# Altium schematic sheet MainPowerSupply.SchDoc
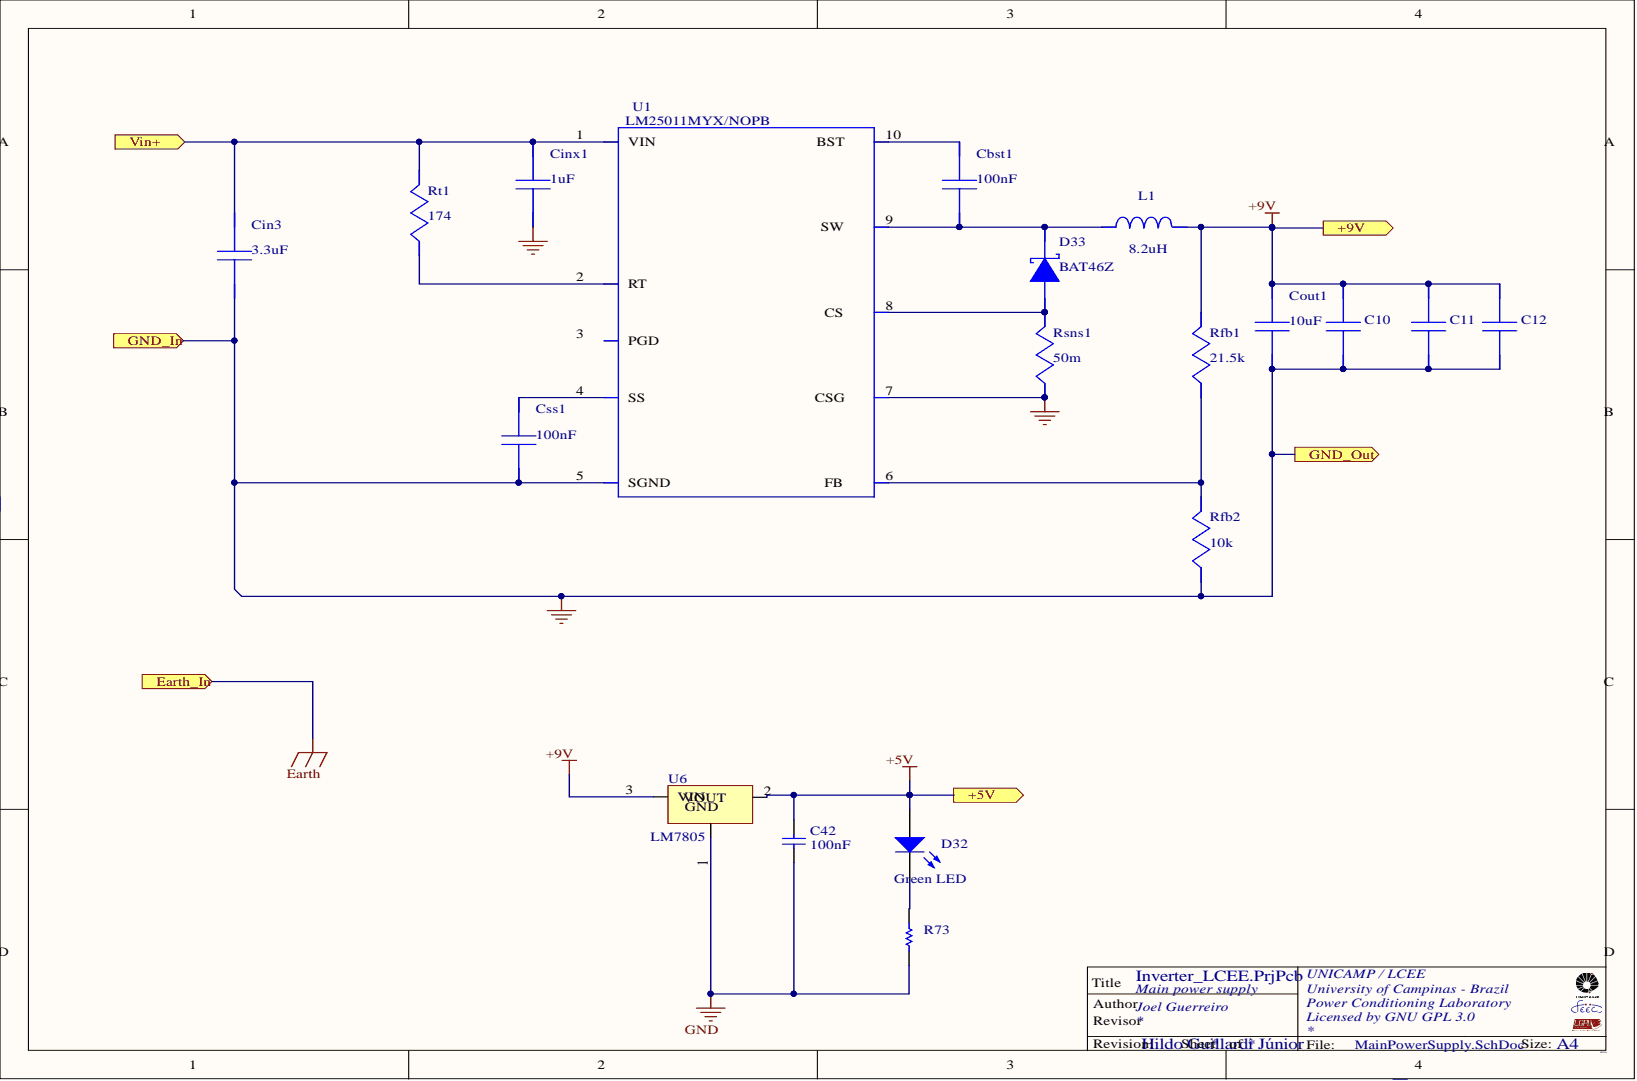
[diagram p0: MainPowerSupply.SchDoc]

## Parts
C10  (no value)  [0805_W]
C11  (no value)  [0805_W]
C12  (no value)  [0805_W]
C42  value 100nF  [0805_W]
Cbst1  value 100nF  [0805_W]
Cin3  value 3.3uF  [1210_W]
Cinx1  value 1uF  [0805_W]
Cout1  value 10uF  [0805_W]
Css1  value 100nF  [0805_W]
D32  value Green LED  [LED_0805]
D33  value BAT46Z  [SOD-123]
L1  value 8.2uH  [SRN8040T - Inductor]
R73  (no value)  [0805_W]
Rfb1  value 21.5k  [0805_W]
Rfb2  value 10k  [0805_W]
Rsns1  value 50m  [0805_W]
Rt1  value 174  [0805_W]
U1  value LM25011MYX/NOPB  [10MSOP]
U6  value LM7805  [LM7805]

## Ports
+5V, +9V, EARTH_IN, GND_IN, GND_OUT, VIN+

## Nets
0: C10.2 C11.2 C12.2 Cin3.2 Cinx1.2 Cout1.2 Css1.2 Rfb2.2 Rsns1.2 U1.5(SGND) U1.7 ; port GND_IN, port GND_OUT
GND: C42.2 R73.1 U6.1
+5V: C42.1 D32.1 U6.2 ; port +5V
+9V: C10.1 C11.1 C12.1 Cout1.1 L1.2 Rfb1.1 U6.3 ; port +9V
NetCbst1_1: Cbst1.1 U1.10
NetCbst1_2: Cbst1.2 D33.2 L1.1 U1.9
NetCin3_1: Cin3.1 Cinx1.1 Rt1.1 U1.1(VIN) ; port VIN+
NetCss1_1: Css1.1 U1.4(SS)
NetD32_2: D32.2 R73.2
NetD33_1: D33.1 Rsns1.1 U1.8
NetRfb1_2: Rfb1.2 Rfb2.1 U1.6
NetRt1_2: Rt1.2 U1.2(RT)
NetU1_3: U1.3(PGD)
EARTH: ; port EARTH_IN
N00132:
N00133:

## Library data of the parts
C10-C12 = GRM21BR61C106KE15L: GRM21BR61C106KE1; Manufacturer Name: MuRata; Component Kind: Standard; Library Name: TI WEBENCH; Manufacturer Part Number: GRM21BR61C106KE15L; PackageReference: GRM21BR61C106KE15L; Value: Value
C42 = Capacitor_nao_polarizado: Capacitor nao polarizado; Component Kind: Standard; Value: Value; Library Name: Sch_LCEE.SchLib
Cbst1 Css1 = CC0805KRX7R8BB104: CC0805KRX7R8BB10; Manufacturer Name: Yageo America; Component Kind: Standard; Library Name: TI WEBENCH; Manufacturer Part Number: CC0805KRX7R8BB104; PackageReference: CC0805KRX7R8BB104; Value: Value
Cin3 = C3225X7S2A335K200AB: C3225X7S2A335K20; Manufacturer Name: TDK; Component Kind: Standard; Library Name: TI WEBENCH; Manufacturer Part Number: C3225X7S2A335K200AB; PackageReference: C3225X7S2A335K200AB; Value: Value
Cinx1 = C1608X5R1H105K080AB: C1608X5R1H105K08; Manufacturer Name: TDK; Component Kind: Standard; Library Name: TI WEBENCH; Manufacturer Part Number: C1608X5R1H105K080AB; PackageReference: C1608X5R1H105K080AB; Value: Value
Cout1 = C0805C106K8PACTU: Manufacturer Name: Kemet; Component Kind: Standard; Description: C0805C106K8PACTU; Library Name: TI WEBENCH; Manufacturer Part Number: C0805C106K8PACTU; PackageReference: C0805C106K8PACTU; Value: Value
D32 = LED0: Typical INFRARED GaAs LED; Component Kind: Standard; LatestRevisionDate: 08-Jul-2005; LatestRevisionNote: Mech Layer 1 removed.; Library Name: Miscellaneous Devices.IntLib; PackageDescription: LED; 2 Leads; PackageReference: LED-0; Published: 8-Jun-2000; Simulation: LED0; Publisher: Altium Limited
D33 = B360A-13-F: Manufacturer Name: Diodes Inc.; Component Kind: Standard; Description: B360A-13-F; Library Name: TI WEBENCH; Manufacturer Part Number: B360A-13-F; PackageReference: B360A-13-F; Value: Value
L1 = SRN8040-8R2Y: Manufacturer Name: Bourns; Component Kind: Standard; Description: SRN8040-8R2Y; Library Name: TI WEBENCH; Manufacturer Part Number: SRN8040-8R2Y; PackageReference: SRN8040-8R2Y; Value: Value
R73 = Res_0805: Resistor; Manufacturer: Generic Components; Class: Passive; Component Kind: Standard; Published: 08/06/2000; SubClass: Resistor; Value: Value; Library Name: Inversor_LCEE.SchLib; Publisher: Altium Hobart Technology Centre
Rfb1 = CRCW040221K5FKED: Manufacturer Name: Vishay-Dale; Component Kind: Standard; Description: CRCW040221K5FKED; Library Name: TI WEBENCH; Manufacturer Part Number: CRCW040221K5FKED; PackageReference: CRCW040221K5FKED; Value: Value
Rfb2 = CRCW040210K0FKED: Manufacturer Name: Vishay-Dale; Component Kind: Standard; Description: CRCW040210K0FKED; Library Name: TI WEBENCH; Manufacturer Part Number: CRCW040210K0FKED; PackageReference: CRCW040210K0FKED; Value: Value
Rsns1 = CRM0805-FW-R050ELF: CRM0805-FW-R050E; Manufacturer Name: Bourns; Component Kind: Standard; Library Name: TI WEBENCH; Manufacturer Part Number: CRM0805-FW-R050ELF; PackageReference: CRM0805-FW-R050ELF; Value: Value
Rt1 = CRCW0402174KFKED: Manufacturer Name: Vishay-Dale; Component Kind: Standard; Description: CRCW0402174KFKED; Library Name: TI WEBENCH; Manufacturer Part Number: CRCW0402174KFKED; PackageReference: CRCW0402174KFKED; Value: Value
U1 = LM25011MYX/NOPB: Manufacturer Name: Texas Instruments; Component Kind: Standard; Description: LM25011MYX/NOPB; Library Name: TI WEBENCH
U6 = LM7805: Component Kind: Standard; Description: LM7805; Library Name: Sch_LCEE.SchLib
